# Altium schematic sheet Sheet1.SchDoc
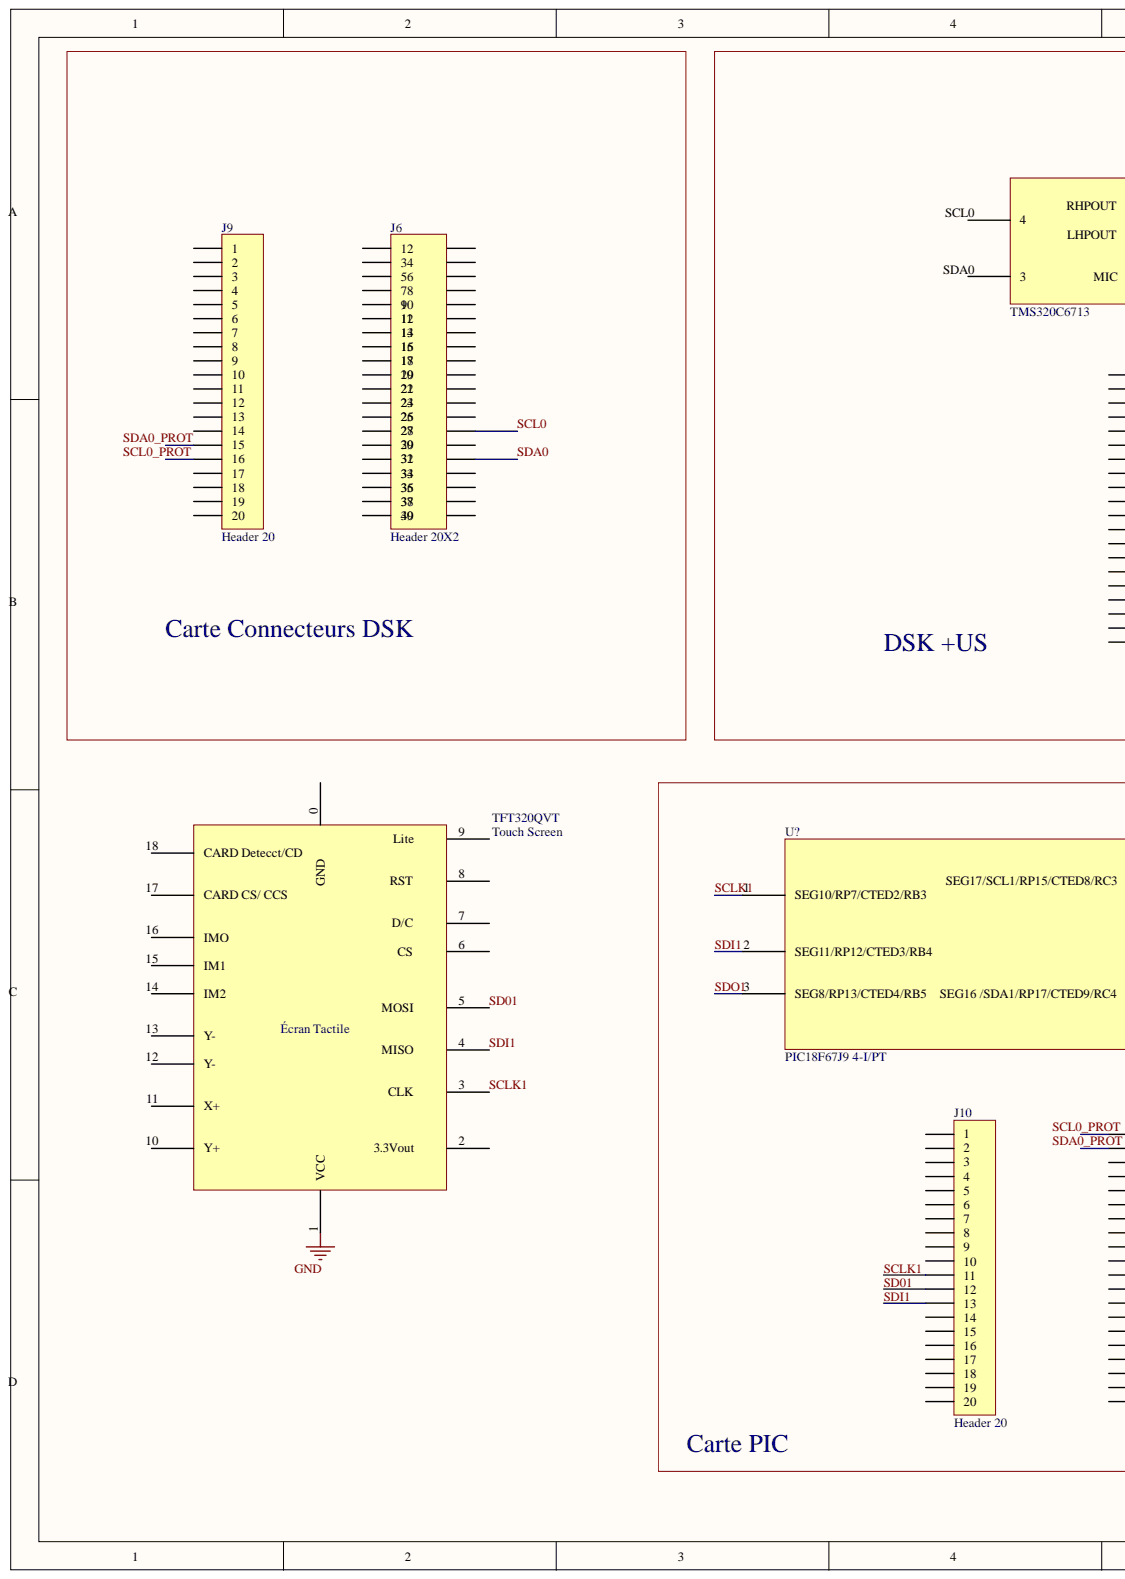
[diagram p0: Sheet1.SchDoc, left]
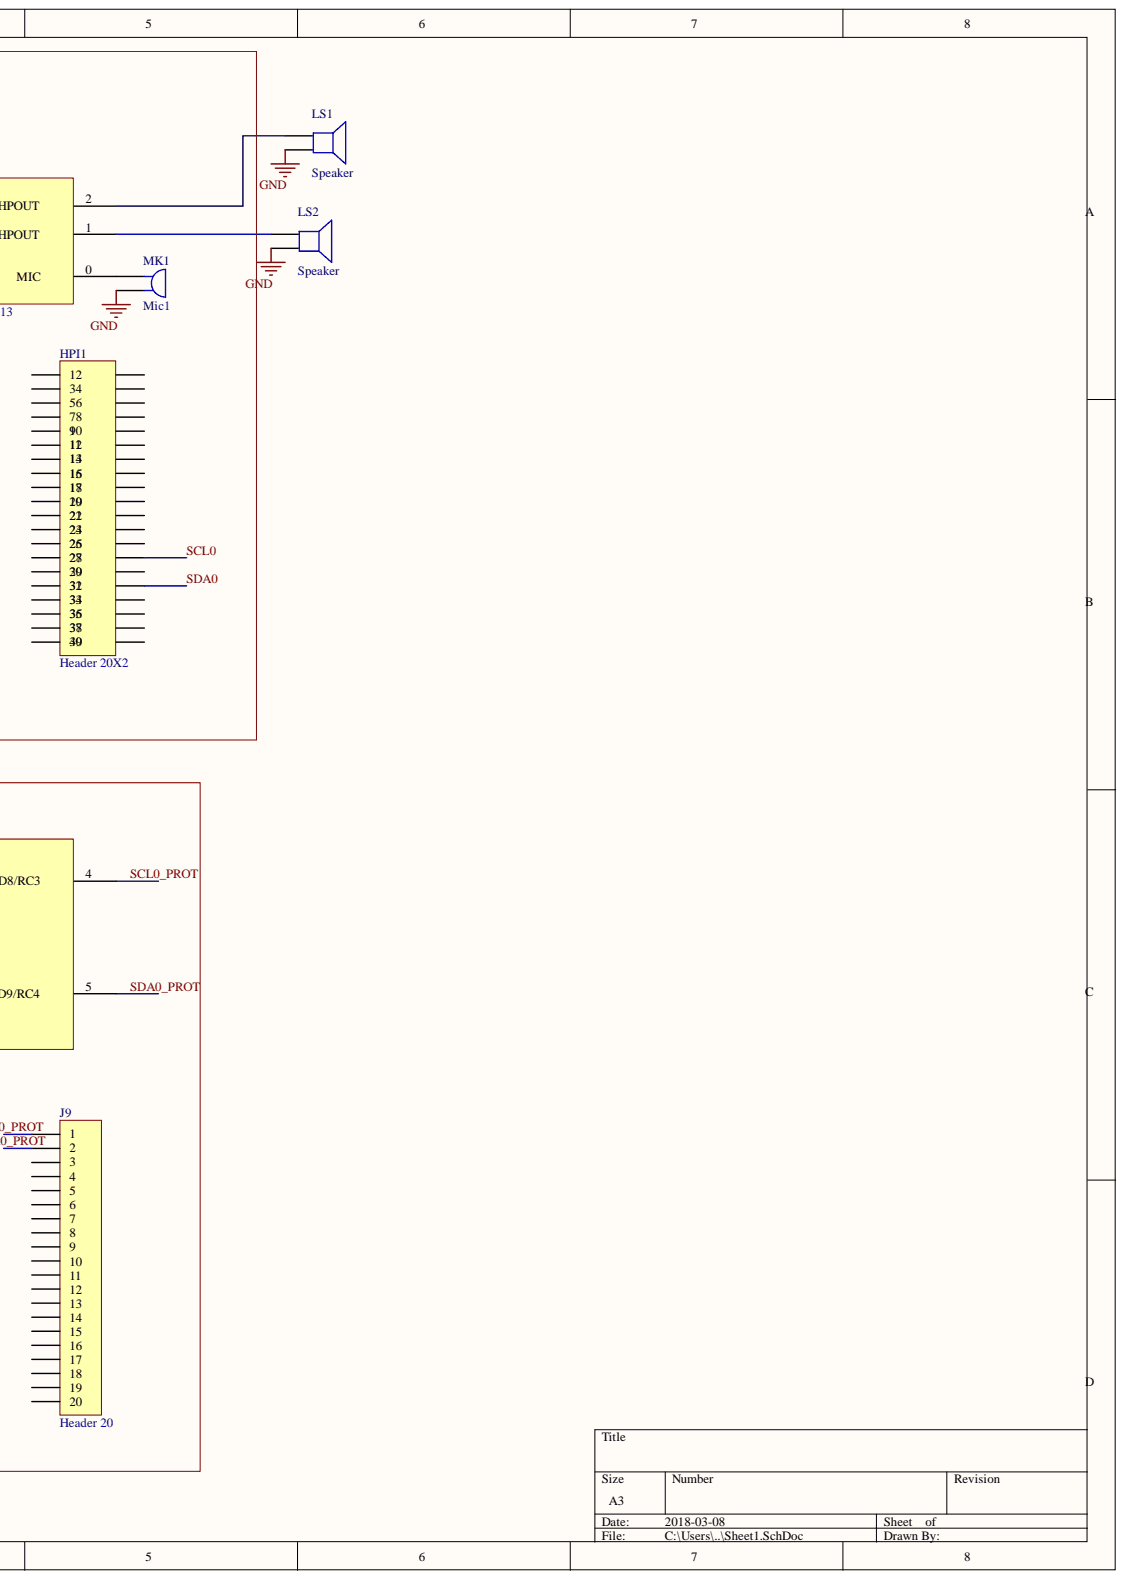
[diagram p1: Sheet1.SchDoc, right]

## Parts
HPI1  Header 20X2  [HDR2X20]
J6  Header 20X2  [HDR2X20]
J9  Header 20  [HDR1X20]
J9  Header 20  [HDR1X20]
J10  Header 20  [HDR1X20]
LS1  Speaker  [PIN2]
LS2  Speaker  [PIN2]
MK1  Mic1  [PIN2]
TFT320QVT  Touch Screen
U?  PIC18F67J9 4-I/PT
  TMS320C6713

## Nets
GND: LS1.2 LS2.2 MK1.2 TFT320QVT.1(VCC)
Net_0: MK1.1 .0
Net_1: LS2.1 .1
Net_2: LS1.1 .2
Net_SCL0: .SCL0
Net_SDA0: .SDA0
SCL0: HPI1.28 J6.28
SCL0_PROT: U?.4
SCLK1: J10.11 TFT320QVT.3 U?.1(SEG10/RP7/CTED2/RB3)
SD01: J10.12 TFT320QVT.5
SDA0: HPI1.32 J6.32
SDA0_PROT: U?.5
SDI1: J10.13 TFT320QVT.4 U?.2(SEG11/RP12/CTED3/RB4)
SDO1: U?.3(SEG8/RP13/CTED4/RB5)

Not connected: HPI1 1 2 3 4 5 6 7 8 9 10 11 12 13 14 15 16 17 18 19 20 21 22 23 24 25 26 27 29 30 31 33 34 35 36 37 38 39 40; J6 1 2 3 4 5 6 7 8 9 10 11 12 13 14 15 16 17 18 19 20 21 22 23 24 25 26 27 29 30 31 33 34 35 36 37 38 39 40; J9 1 2 3 4 5 6 7 8 9 10 11 12 13 14 15 16 17 18 19 20; J10 1 2 3 4 5 6 7 8 9 10 14 15 16 17 18 19 20; TFT320QVT 0 2 6 7 8 9 10(Y+) 11(X+) 12(Y-) 13(Y-) 14(IM2) 15(IM1) 16(IMO) 17(CARD_CS/_CCS) 18(CARD_Detecct/CD)

## Library data of the parts
HPI1 J6 = Header 20X2: Header, 20-Pin, Dual row; Component Kind: Standard; LatestRevisionDate: 17-Jul-2002; LatestRevisionNote: Re-released for DXP Platform.; Library Name: Miscellaneous Connectors.IntLib; Signal Integrity: Connector; Publisher: Altium Limited
J9 J9 J10 = Header 20: Header, 20-Pin; Component Kind: Standard; LatestRevisionDate: 17-Jul-2002; LatestRevisionNote: Re-released for DXP Platform.; Library Name: Miscellaneous Connectors.IntLib; Signal Integrity: Connector; Publisher: Altium Limited
LS1 LS2 = Speaker: Loudspeaker; Component Kind: Standard; LatestRevisionDate: 17-Jul-2002; LatestRevisionNote: Re-released for DXP Platform.; Library Name: Miscellaneous Devices.IntLib; PackageReference: PIN2; Published: 24-Mar-1999; Publisher: Altium Limited
MK1 = Mic1: Microphone; Component Kind: Standard; LatestRevisionDate: 17-Jul-2002; LatestRevisionNote: Re-released for DXP Platform.; Library Name: Miscellaneous Devices.IntLib; PackageReference: PIN2; Published: 24-Mar-1999; Publisher: Altium Limited
U? = Component_2: Component Kind: Standard; Library Name: Schlib1.SchLib
 = DSK: Component Kind: Standard; Library Name: Schlib1.SchLib
TFT320QVT = Touch Screen: Component Kind: Standard; Library Name: Schlib1.SchLib
